# Altium schematic sheet 13-Programmer.SchDoc
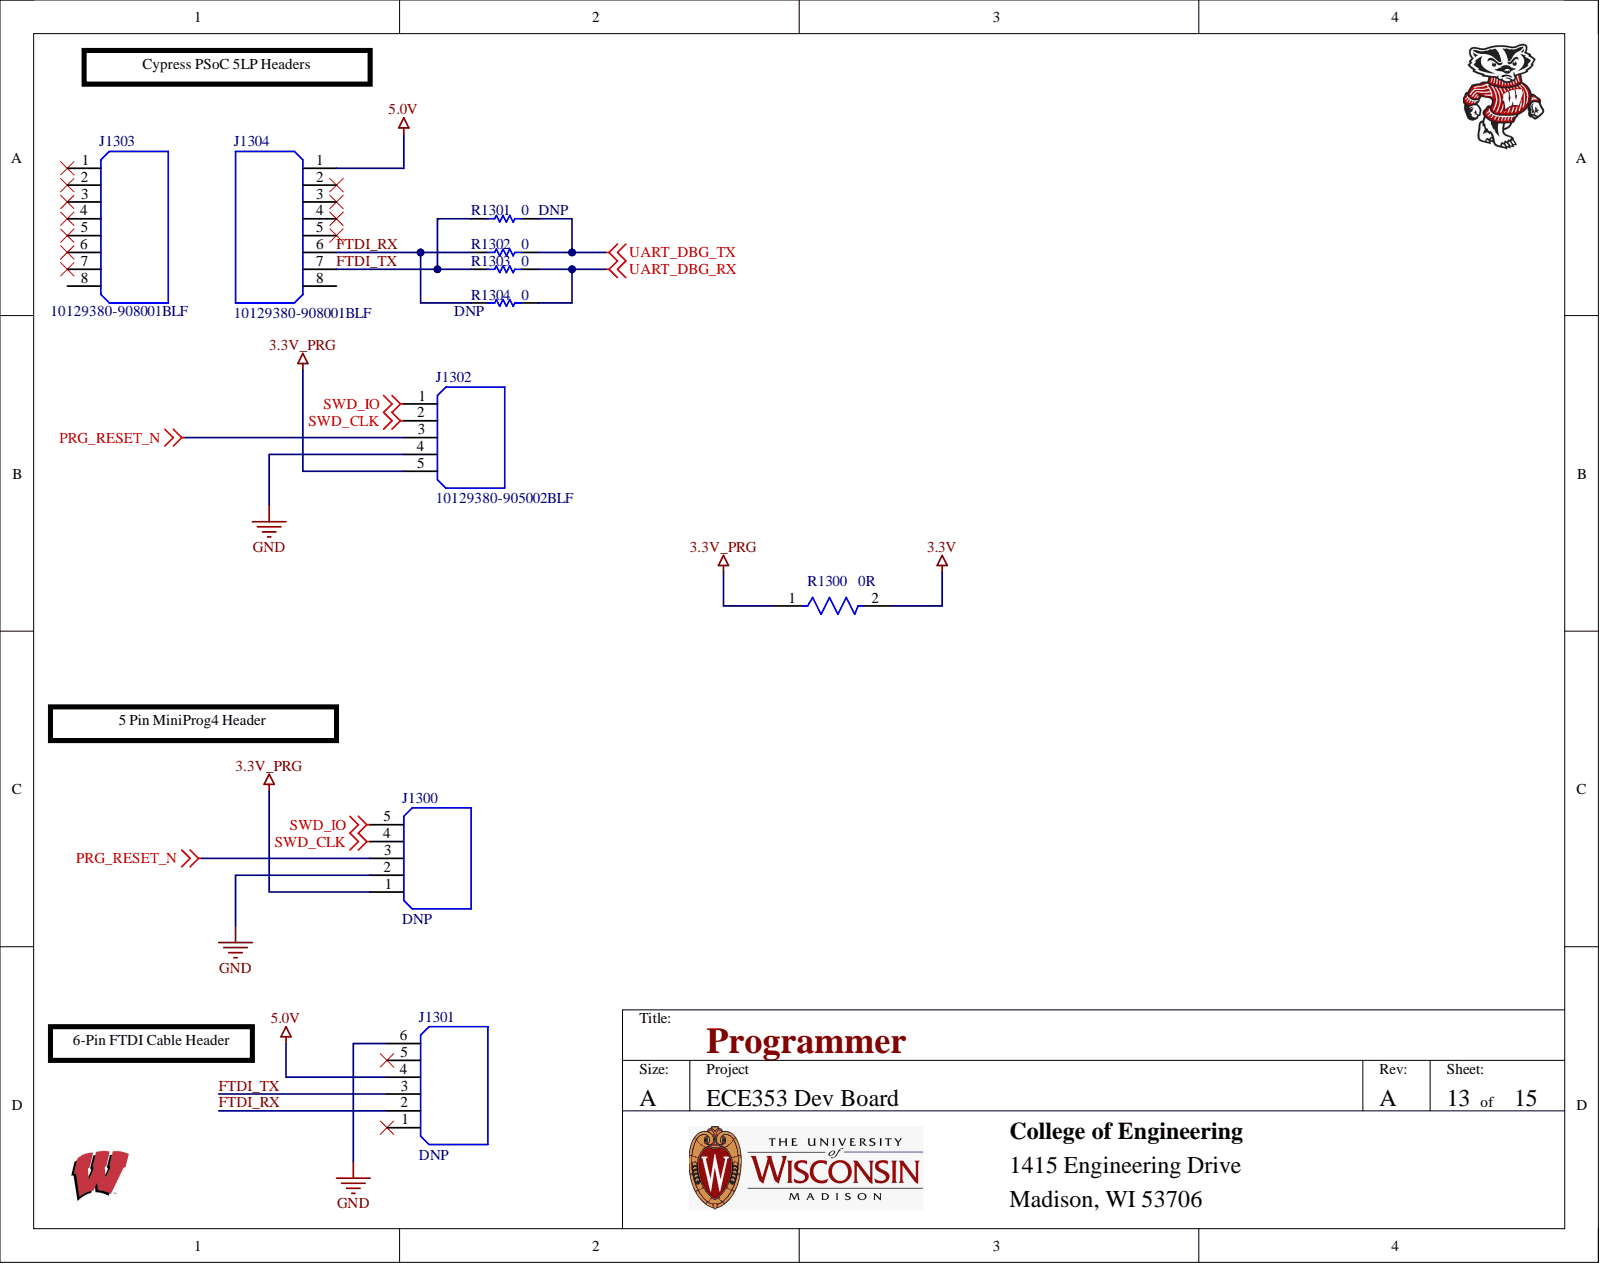
[diagram p0: 13-Programmer.SchDoc]

## Parts
J1300  DNP  [SAMTEC_TSM-105-01-L-SH-P-TR]  (no BOM)
J1301  DNP  [SAMTEC_TSM-106-01-F-SH]  (no BOM)
J1302  10129380-905002BLF  [AMPHENOL_10129380-905002BLF]
J1303  10129380-908001BLF  [AMPHENOL_10129380-908001BLF]
J1304  10129380-908001BLF  [AMPHENOL_10129380-908001BLF]
R1300  Resistance: 0R  [FP-RC1206-0_65-IPC_C]  (hidden comment: RC1206FR-070RL)
R1301  Usage: DNP  [RESC2013X60X35ML10T20]  (hidden comment: RC0805JR-070RL)  (no BOM)
R1302  RC0805JR-070RL  [RESC2013X60X35ML10T20]  (value not drawn)
R1303  RC0805JR-070RL  [RESC2013X60X35ML10T20]  (value not drawn)
R1304  Usage: DNP  [RESC2013X60X35ML10T20]  (hidden comment: RC0805JR-070RL)  (no BOM)

## Nets
3.3V: R1300.2
3.3V_PRG: J1300.1 J1302.5 R1300.1
5.0V: J1301.4 J1304.1
GND: J1300.2 J1301.6 J1302.4
PRG_RESET_N: J1300.3 J1302.3
SWD_CLK: J1300.4 J1302.2
SWD_IO: J1300.5 J1302.1
UART_DBG_RX: R1303.2 R1304.2
UART_DBG_TX: R1301.2 R1302.2
FTDI_RX: J1301.2 J1304.6 R1302.1 R1304.1
FTDI_TX: J1301.3 J1304.7 R1301.1 R1303.1

Not connected: J1301 1 5; J1303 1 2 3 4 5 6 7 8; J1304 2 3 4 5 8

## Library data of the parts
J1300 = TSM-105-01-T-SH: Conn Unshrouded Header HDR 5 POS 2.54mm Solder RA Side Entry SMD Tube; Manufacturer 1: Samtec; Gender: Male; Max Current Rating: 7A; Max Voltage Rating (AC): 475V; Number of Contacts: 5; Category: Headers and Wire Housings; ComponentLink1Description: http://components.arrow.com/part/dataview/2684980S7814043N1004?src-supplier=Arrow+Electronics; ComponentLink1URL: http://components.arrow.com/part/dataview/2684980S7814043N1004?src-supplier=Arrow+Electronics; ComponentLink2Description: http://www.samtec.com/documents/webfiles/pdf/TSM.PDF?src-supplier=Avnet; ComponentLink2URL: http://www.samtec.com/documents/webfiles/pdf/TSM.PDF?src-supplier=Avnet; ComponentLink3Description: http://suddendocs.samtec.com/prints/tsm-1xx-xx-xxx-xx-x-xxx-x-mkt.pdf?src-supplier=Digi-Key; ComponentLink3URL: http://suddendocs.samtec.com/prints/tsm-1xx-xx-xxx-xx-x-xxx-x-mkt.pdf?src-supplier=Digi-Key; ComponentLink4Description: http://www.farnell.com/datasheets/1927672.pdf?src-supplier=Farnell; ComponentLink4URL: http://www.farnell.com/datasheets/1927672.pdf?src-supplier=Farnell; ComponentLink5Description: http://components.arrow.com/part/dataview/2684865S6076461N1004?src-supplier=Arrow+Electronics; ComponentLink5URL: http://components.arrow.com/part/dataview/2684865S6076461N1004?src-supplier=Arrow+Electronics; Contact Material: Bronze; Contact Plating: Tin; Depth: 10.92mm; Housing Color: Black; Housing Material: Polymer; Lead Free: Lead Free; Length: 12.7mm; Library Name: ece353-2022.SchLib; Manufacturer Part Number 1: TSM-105-01-T-SH; Max Operating Temperature: 105°C; Min Operating Temperature: -55°C; Number of Rows: 1; Orientation: Right Angle; Packaging: Bulk; Pitch: 2.54mm; Pricing 1: 1=0.94, 10=0.792, 100=0.711, 500=0.58176, 1000=0.51712, 5000=0.45248, 10000=0.42986 (USD); Radiation Hardening: No; Reach SVHC Compliant: No; RoHS: Yes; RoHS Compliant: Yes; Stock 1: 1765; Supplier 1: Digi-Key; Supplier Part Number 1: SAM10283-ND; Termination: Solder
J1301 = TSM-106-01-F-SH: "This surface mount .025” square post terminal strip features single; Manufacturer 1: Samtec; Connector Type: Header; Gender: Male; Max Current Rating: 7A; Max Voltage Rating (AC): 475V; Number of Contacts: 6; Pitch: 2.54mm; Category: Headers and Wire Housings; ComponentLink1Description: http://components.arrow.com/part/dataview/43114377S2967N1004?src-supplier=Arrow+Electronics; ComponentLink1URL: http://components.arrow.com/part/dataview/43114377S2967N1004?src-supplier=Arrow+Electronics; ComponentLink2Description: http://www.samtec.com/documents/webfiles/pdf/TSM.PDF?src-supplier=Avnet; ComponentLink2URL: http://www.samtec.com/documents/webfiles/pdf/TSM.PDF?src-supplier=Avnet; Contact Finish - Mating: GOLD; Contact Material: Bronze; Contact Plating: Gold; Contact Shape: Square; Contact Type: Male Pin; Depth: 10.92mm; Fastening Type: Pull; Flammability Rating: UL94 V-0; Housing Color: Black; Housing Material: Polymer; Insulation Color: Black; Insulation Material: Polymer; Lead Free: Lead Free; Length: 15.24mm; Library Name: ece353-2022.SchLib; Manufacturer Part Number 1: TSM-106-01-F-SH; Max Operating Temperature: 125°C; Min Operating Temperature: -55°C; Number of Positions: 6; Number of Rows: 1; Orientation: Right Angle; Packaging: Rail/Tube; Pricing 1: 1=1.36, 10=1.187, 100=1.0605, 500=0.88376, 1000=0.7575, 5000=0.67165, 10000=0.6565 (USD); Radiation Hardening: No; RoHS: Yes; RoHS Compliant: Yes; Series: TSM; Stock 1: 3083; Supplier 1: Digi-Key; Supplier Part Number 1: SAM15897-ND; Termination: Solder
J1302 = 10129380-905002BLF: Conn Unshrouded Header HDR 5 POS 2.54mm Solder ST SMD Poly Bag; Manufacturer 1: ICC; MF: AMPHENOL ICC / FCI; Availability: In Stock; Check_prices: https://www.snapeda.com/parts/10129380-905002BLF/Amphenol/view-part/?ref=eda; Component Kind: Standard; Library Name: ece353-2022.SchLib; Manufacturer Part Number 1: 10129380-905002BLF; MP: 10129380-905002BLF; Package: None; Price: None; Purchase-URL: https://pricing.snapeda.com/search/part/10129380-905002BLF/?ref=eda; RoHS: No; SnapEDA_Link: https://www.snapeda.com/parts/10129380-905002BLF/Amphenol/view-part/?ref=snap; Supplier 1: Digi-Key; Supplier Part Number 1: 10129380-905002BLF-ND
J1303 J1304 = 10129380-908001BLF: Conn Unshrouded Header HDR 8 POS 2.54mm Solder ST SMD Poly Bag; Manufacturer 1: ICC; MF: Amphenol ICC (FCI); Availability: In Stock; Check_prices: https://www.snapeda.com/parts/10129380-908001BLF/Amphenol+FCI/view-part/?ref=eda; Component Kind: Standard; Library Name: ece353-2022.SchLib; Manufacturer Part Number 1: 10129380-908001BLF; MP: 10129380-908001BLF; Package: None; Price: None; Purchase-URL: https://pricing.snapeda.com/search/part/10129380-908001BLF/?ref=eda; RoHS: No; SnapEDA_Link: https://www.snapeda.com/parts/10129380-908001BLF/Amphenol+FCI/view-part/?ref=snap; Supplier 1: Digi-Key; Supplier Part Number 1: 10129380-908001BLF-ND
R1300 = CMP-2003-03534-2: RES SMD 0 OHM JUMPER 1/4W 1206; Manufacturer 1: Yageo; Max Power Dissipation: 250mW; Temperature Coefficient: 200ppm/°C; Tolerance: 1%; Case Code (Imperial): 1206; Case Code (Metric): 3216; Case/Package: 1206; Category: Chip SMD Resistors; Component Kind: Standard; ComponentLink1Description: https://datasheet.ciiva.com/17032/pyu-rc1206-51-rohs-l-4-17032972.pdf?src-supplier=Future+Electronics; ComponentLink1URL: https://datasheet.ciiva.com/17032/pyu-rc1206-51-rohs-l-4-17032972.pdf?src-supplier=Future+Electronics; Contact Plating: Tin; Features: Moisture Resistant; Height: 0.026inch; Lead Free: Lead Free; Length: 0.122inch; Library Name: Altium Content Vault; Manufacturer Part Number 1: RC1206FR-070RL; Max Operating Temperature: 155°C; Min Operating Temperature: -55°C; Mount: Surface Mount; Number of Terminations: 2; Packaging: Tape and Reel; Power Rating: 250mW; Pricing 1: 1=0.1, 10=0.058, 25=0.0424, 50=0.0322, 100=0.0237, 250=0.01808, 500=0.01448, 1000=0.01065 (USD); Radiation Hardening: No; Resistor Type: Thick Film; RoHS: Yes; RoHS Compliant: Yes; Series: RC; Size Code: 1206; Stock 1: 498794; Supplier 1: Digi-Key; Supplier Part Number 1: YAG3367CT-ND; Width: 0.063inch
R1301-R1304 = CMP-2100-03673-1: Chip Resistor, 0 Ohm, Jumper, +/- 5%, 0.125 W, -55 to 155 degC, 0805 (2012 Metric), RoHS, Tape and Reel; Manufacturer: Yageo; Max Power Dissipation: 125mW; Resistance: 0; Temperature Coefficient: 200ppm/degreesC; Voltage Rating: 150V; Case Code (Imperial): 0805; Case Code (Metric): 2012; Case/Package: 0805; Category: Chip SMD Resistors; ComponentLink1Description: https://datasheet.ciiva.com/7941/1738694-7941862.pdf?src-supplier=Element14; ComponentLink1URL: https://datasheet.ciiva.com/7941/1738694-7941862.pdf?src-supplier=Element14; Composition: ThickFilm; Construction: Rectangular; Contact Plating: Tin; Datasheet URL: http://www.yageo.com/NewPortal/yageodocoutput?fileName=/pdf/R-Chip/PYu-RC_Group_51_RoHS_L_5.pdf; Datasheet Version: Rev. 5, 10/2016; Depth: 1.25mm; Features: Moisture Resistant; Height: 0.5mm; Lead Free: LeadFree; Length: 2mm; Library Name: Altium Content Vault; Manufacturer 1: Yageo; Manufacturer Part Number 1: RC0805JR-070RL; Manufacturer URL: http://www.yageo.com/; Max Operating Temperature: 155degC; Military Standard: Not; Min Operating Temperature: -55degC; Number of Pins: 2; Number of Terminations: 2; Package Description: 2-Pin Surface Mounted Device, Body 2 x 1.25 mm; Package Reference: 0805; Packaging: TapeandReel; Power Rating: 125mW; Pricing 1: 1=0.10000, 10=0.02800, 25=0.02040, 50=0.01560, 100=0.01150, 250=0.00876, 500=0.00702, 1000=0.00517 (USD); Radiation Hardening: No; REACH SVHC: Unknown; Resistance Tolerance: 5%; Resistor Type: Thick Film; RoHS: Yes; RoHS Compliant: Yes; RoHSCompliant: true; Series: RC; Size Code: 0805; Stock 1: 4224895; Supplier 1: Digi-Key; Supplier Part Number 1: 311-0.0ARCT-ND; Technology: THICK FILM; Termination: SMD/SMT; Tolerance: 5%; Voltage Rating (DC): 150V; Width: 1.25mm
  R1302 R1303: Component Kind: Standard
